# Altium schematic sheet Connectors.SchDoc
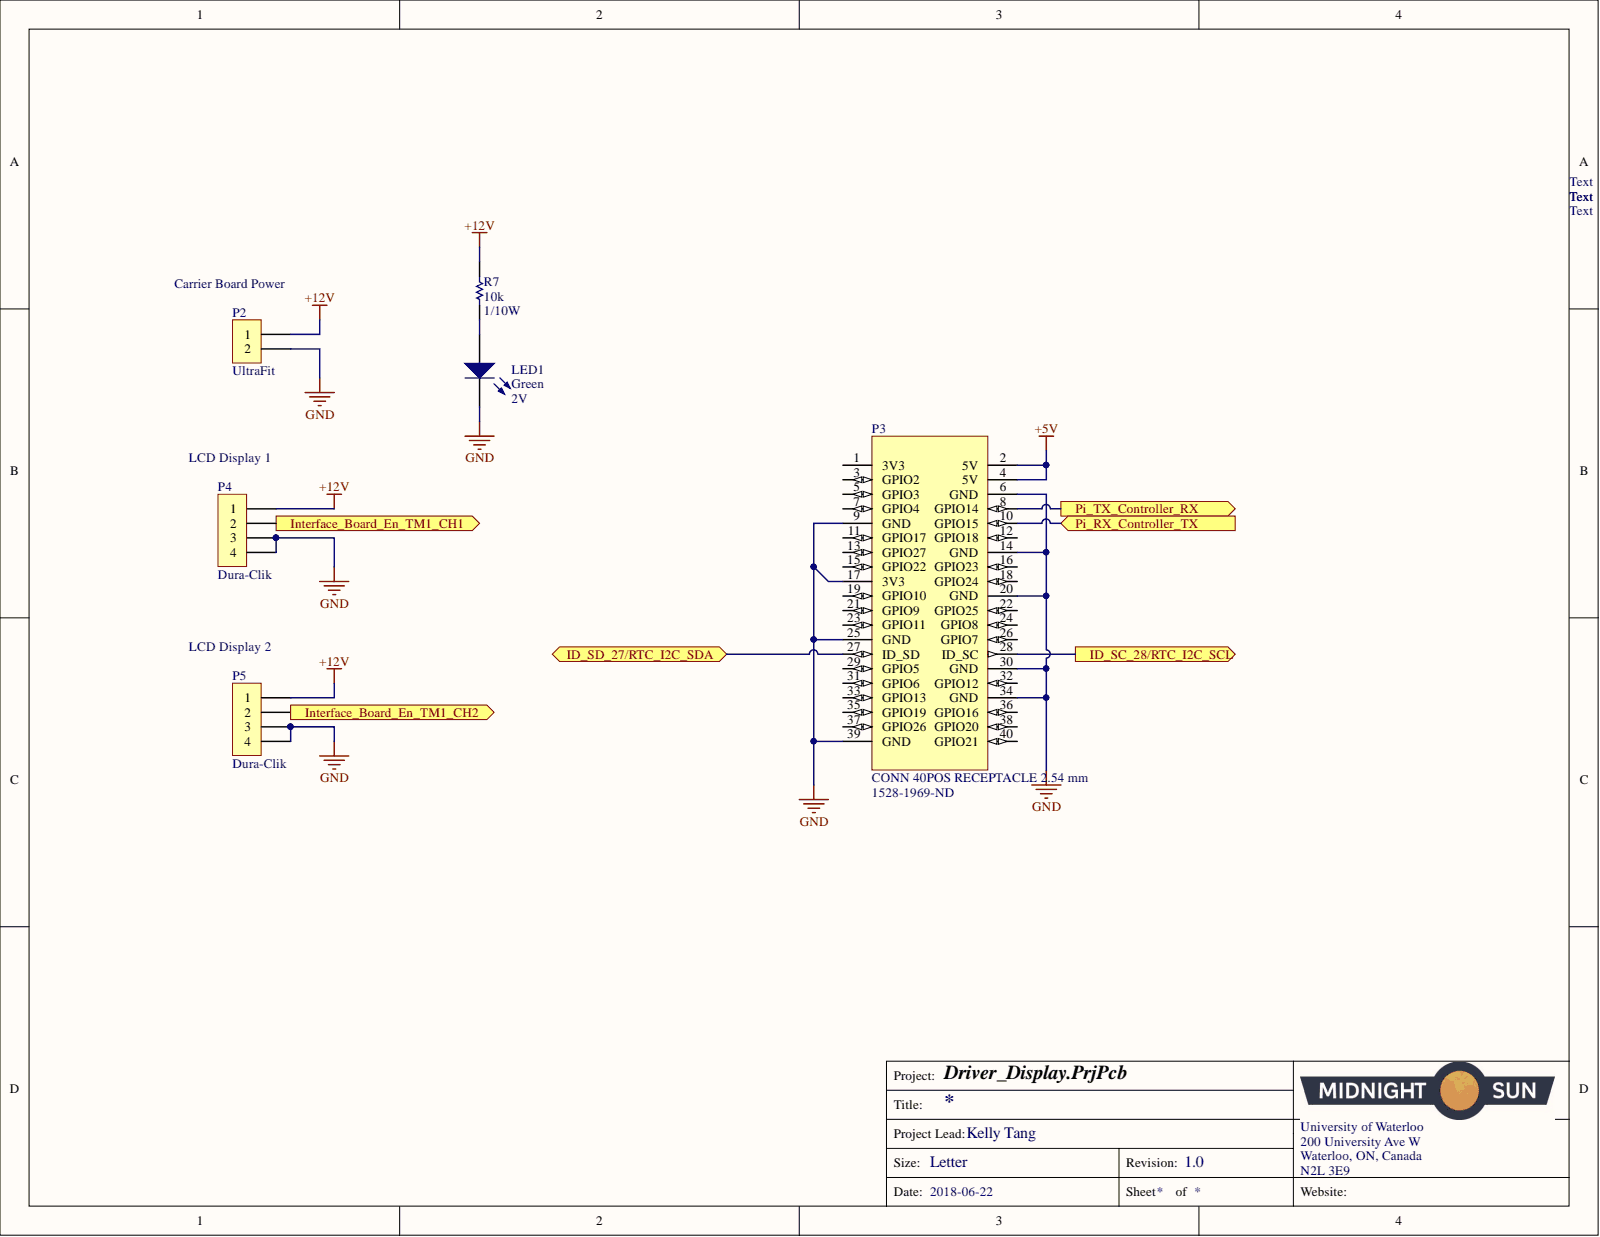
[diagram p0: Connectors.SchDoc]

## Parts
LED1  Color: Green  Voltage - Forward (Vf) (Typ): 2V  [LED_0603]  (hidden comment: LED GREEN CLEAR 2V 0603)
P2  Series: UltraFit  [CONN, 2POS ULTRA-FIT]  (hidden comment: CONN 2POS ULTRA-FIT 0.138")
P3  CONN 40POS RECEPTACLE 2.54 mm  Supplier Part Number 1: 1528-1969-ND  [CONN 40POS RECEPTACLE 2.54 MM]
P4  Dura-Clik  [CONN, 4POS DURA-CLIK VERT]
P5  Dura-Clik  [CONN, 4POS DURA-CLIK VERT]
R7  Power: 1/10W  Resistance: 10k  [RES, 0603]  (hidden comment: RES 10K OHM 1% 1/10W 0603)

## Ports
ID_SC_28/RTC_I2C_SCL, ID_SD_27/RTC_I2C_SDA, Interface_Board_En_TM1_CH1, Interface_Board_En_TM1_CH2, Pi_RX_Controller_TX, Pi_TX_Controller_RX

## Nets
+5V: P3.2(5V) P3.4(5V)
+12V: P2.1 P4.1 P5.1 R7.2
GND: LED1.2 P2.2 P3.6(GND) P3.9(GND) P3.14(GND) P3.17(3V3) P3.20(GND) P3.25(GND) P3.30(GND) P3.34(GND) P3.39(GND) P4.3 P4.4 P5.3 P5.4
ID_SC_28/RTC_I2C_SCL: P3.28(ID_SC) ; port ID_SC_28/RTC_I2C_SCL
ID_SD_27/RTC_I2C_SDA: P3.27(ID_SD) ; port ID_SD_27/RTC_I2C_SDA
Interface_Board_En_TM1_CH1: P4.2 ; port Interface_Board_En_TM1_CH1
Interface_Board_En_TM1_CH2: P5.2 ; port Interface_Board_En_TM1_CH2
Pi_RX_Controller_TX: P3.10(GPIO15) ; port Pi_RX_Controller_TX
Pi_TX_Controller_RX: P3.8(GPIO14) ; port Pi_TX_Controller_RX
NetLED1_1: LED1.1 R7.1

Not connected: P3 1(3V3) 3(GPIO2) 5(GPIO3) 7(GPIO4) 11(GPIO17) 12(GPIO18) 13(GPIO27) 15(GPIO22) 16(GPIO23) 18(GPIO24) 19(GPIO10) 21(GPIO9) 22(GPIO25) 23(GPIO11) 24(GPIO8) 26(GPIO7) 29(GPIO5) 31(GPIO6) 32(GPIO12) 33(GPIO13) 35(GPIO19) 36(GPIO16) 37(GPIO26) 38(GPIO20) 40(GPIO21)

## Library data of the parts
LED1 = LED GREEN CLEAR 2V 0603: Green 572nm LED Indication - Discrete 2V 0603 (1608 Metric); Manufacturer: Wurth Electronics Inc.; Manufacturer Part Number: 150060VS75000; Current - Test: 20mA; Lens Color: Colorless; Lens Style/Size: Rectangle with Flat Top, 1.10mm x 0.70mm; Millicandela Rating: 40mcd; Viewing Angle: 140°; Wavelength - Dominant: 570nm; Category: Optoelectronics; Component Kind: Standard; ComponentLink1Description: http://katalog.we-online.de/led/datasheet/150060VS75000.pdf; ComponentLink1URL: http://katalog.we-online.de/led/datasheet/150060VS75000.pdf; Features: -; Height (Max): 0.80mm; Lens Transparency: Clear; Mounting Type: Surface Mount; Package / Case: 0603 (1608 Metric); Packaging: Cut Tape (CT); Part Status: Active; Pricing 1: 1=0.21, 100=0.1617, 500=0.13964 (CAD); RoHS: RoHS Compliant; Size / Dimension: 1.60mm L x 0.80mm W; Stock 1: 9408; Supplier 1: Digi-Key; Supplier Device Package: 0603; Supplier Part Number 1: 732-4980-1-ND; Wavelength - Peak: 572nm; Library Name: Schematic Diagrams.SchLib
P2 = CONN 2POS ULTRA-FIT 0.138": 2 Positions Header, Shrouded Connector 0.138" (3.50mm) Through Hole Gold; Manufacturer 1: Molex, LLC; Manufacturer Part Number 1: 1722861302; Contact Length - Post: 0.126" (3.20mm); Mated Stacking Heights: 26.99mm; Material Flammability Rating: UL94 V-0; Number of Positions: 2; Number of Positions Loaded: All; Shrouding: Shrouded - 4 Wall; Style: Board to Board or Cable; Voltage: 400V; Component Kind: Standard; ComponentLink1Description: http://www.molex.com/pdm_docs/sd/1722861302_sd.pdf; ComponentLink1URL: http://www.molex.com/pdm_docs/sd/1722861302_sd.pdf; Connector Type: Header; Contact Finish - Mating: Gold; Contact Finish - Post: Tin; Contact Finish Thickness - Mating: 30µin (0.76µm); Contact Finish Thickness - Post: 60µin (1.52µm); Contact Length - Mating: -; Contact Material: Copper Alloy; Contact Shape: Square; Contact Type: Male Pin; Current Rating: -; Fastening Type: Latch Holder; Ingress Protection: -; Insulation Color: Black; Insulation Height: 0.465" (11.80mm); Insulation Material: Liquid Crystal Polymer (LCP); Mounting Type: Through Hole; Number of Rows: 1; Operating Temperature: -40°C ~ 120°C; Overall Contact Length: -; Packaging: Tray; Part Status: Active; Pitch - Mating: 0.138" (3.50mm); Row Spacing - Mating: -; Supplier 1: Digi-Key; Supplier Part Number 1: WM11673-ND; Termination: Kinked Pin, Solder; Library Name: Schematic Diagrams.SchLib
P3 = CONN 40POS RECEPTACLE 2.54 mm: GPIO HEADER FOR RASPBERRYPI A+/B; Manufacturer: Adafruit Industries; Manufacturer Part Number: 1992; Component Kind: Standard; ComponentLink1Description: gpio header raspberry pi; ComponentLink1URL: https://www.digikey.ca/product-detail/en/adafruit-industries-llc/1992/1528-1969-ND/6827092; Pricing 1: 1=2.95 (USD); Stock 1: 175; Supplier 1: Digi-Key; Library Name: Schematic Diagrams.SchLib
P4 P5 = CONN 4POS DURA-CLIK 0.079": 4 Positions Header Connector 0.079" (2.00mm) Surface Mount Tin; Manufacturer: Molex, LLC; Manufacturer Part Number: 5600200420; Mated Stacking Heights: 9.3mm; Number of Positions: 4; Number of Positions Loaded: All; Shrouding: Shrouded - 4 Wall; Style: Board to Cable/Wire; Applications: Automotive, Industrial; Category: Connectors, Interconnects; Component Kind: Standard; ComponentLink1Description: http://www.molex.com/pdm_docs/sd/5600200420_sd.pdf; ComponentLink1URL: http://www.molex.com/pdm_docs/sd/5600200420_sd.pdf; Connector Type: Header; Contact Finish - Mating: Tin; Contact Finish - Post: Tin; Contact Finish Thickness - Mating: -; Contact Finish Thickness - Post: -; Contact Length - Mating: -; Contact Length - Post: -; Contact Material: Copper Alloy; Contact Shape: Square; Contact Type: Male Pin; Current Rating: -; Fastening Type: Latch Lock; Features: Solder Retention; Ingress Protection: -; Insulation Color: Natural; Insulation Height: 0.315" (8.00mm); Insulation Material: Polyamide (PA), Nylon; Material Flammability Rating: -; Mounting Type: Surface Mount; Number of Rows: 1; Operating Temperature: -; Overall Contact Length: -; Packaging: Cut Tape (CT); Part Status: Active; Pitch - Mating: 0.079" (2.00mm); Pricing 1: 1=2.23, 10=2.022, 25=1.898, 50=1.8156, 100=1.733 (CAD); RoHS: RoHS Compliant; Row Spacing - Mating: -; Stock 1: 9367; Supplier 1: Digi-Key; Supplier Part Number 1: WM10864CT-ND; Termination: Solder; Voltage Rating: -; Library Name: Schematic Diagrams.SchLib
R7 = RES 10K OHM 1% 1/10W 0603: RES SMD 10K OHM 1% 1/10W 0603; Manufacturer 1: Yageo; Manufacturer Part Number 1: RC0603FR-0710KL; Temperature Coefficient: ±100ppm/°C; Component Kind: Standard; ComponentLink1Description: http://www.yageo.com/documents/recent/PYu-RC_Group_51_RoHS_L_7.pdf; ComponentLink1URL: http://www.yageo.com/documents/recent/PYu-RC_Group_51_RoHS_L_7.pdf; Composition: Thick Film; Failure Rate: -; Height - Seated (Max): 0.022" (0.55mm); Operating Temperature: -55°C ~ 155°C; Package / Case: 0603 (1608 Metric); Packaging: Cut Tape (CT); Part Status: Active; Size / Dimension: 0.063" L x 0.031" W (1.60mm x 0.80mm); Supplier 1: Digi-Key; Supplier Device Package: 0603; Supplier Part Number 1: 311-10.0KHRCT-ND; Tolerance: ±1%; Library Name: Schematic Diagrams.SchLib
